# Altium schematic sheet main.SchDoc(main)
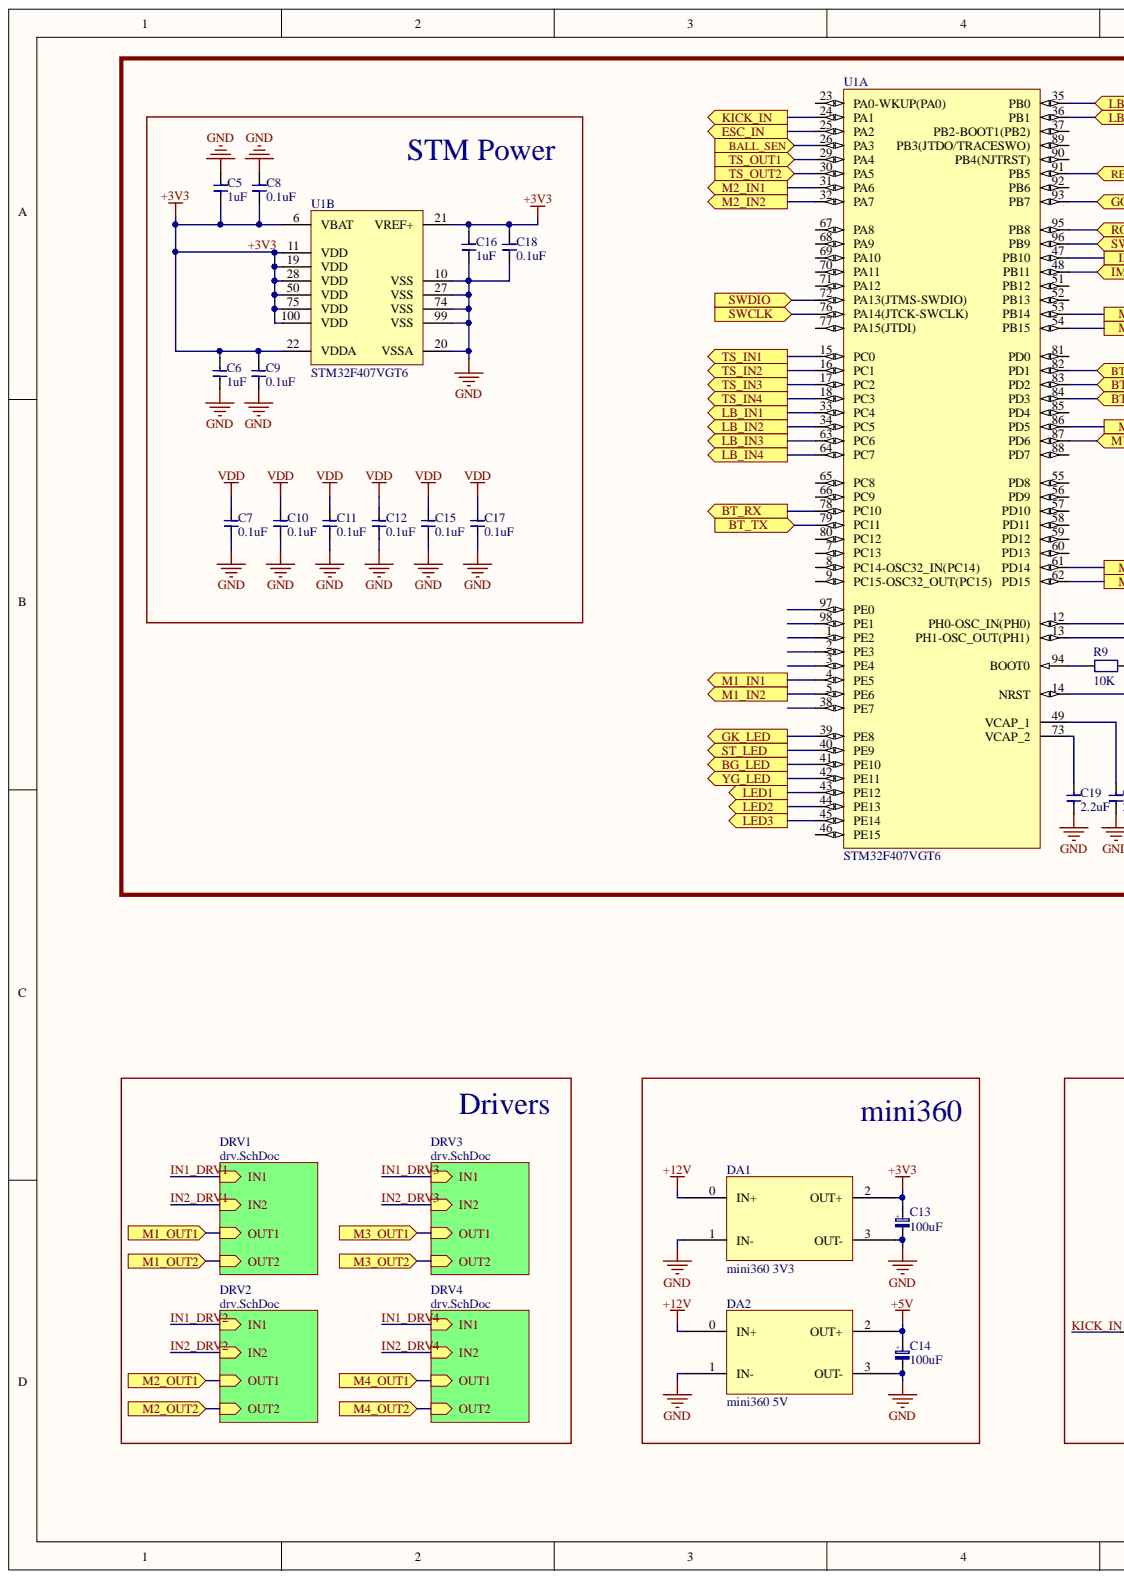
[diagram p0: main.SchDoc, left]
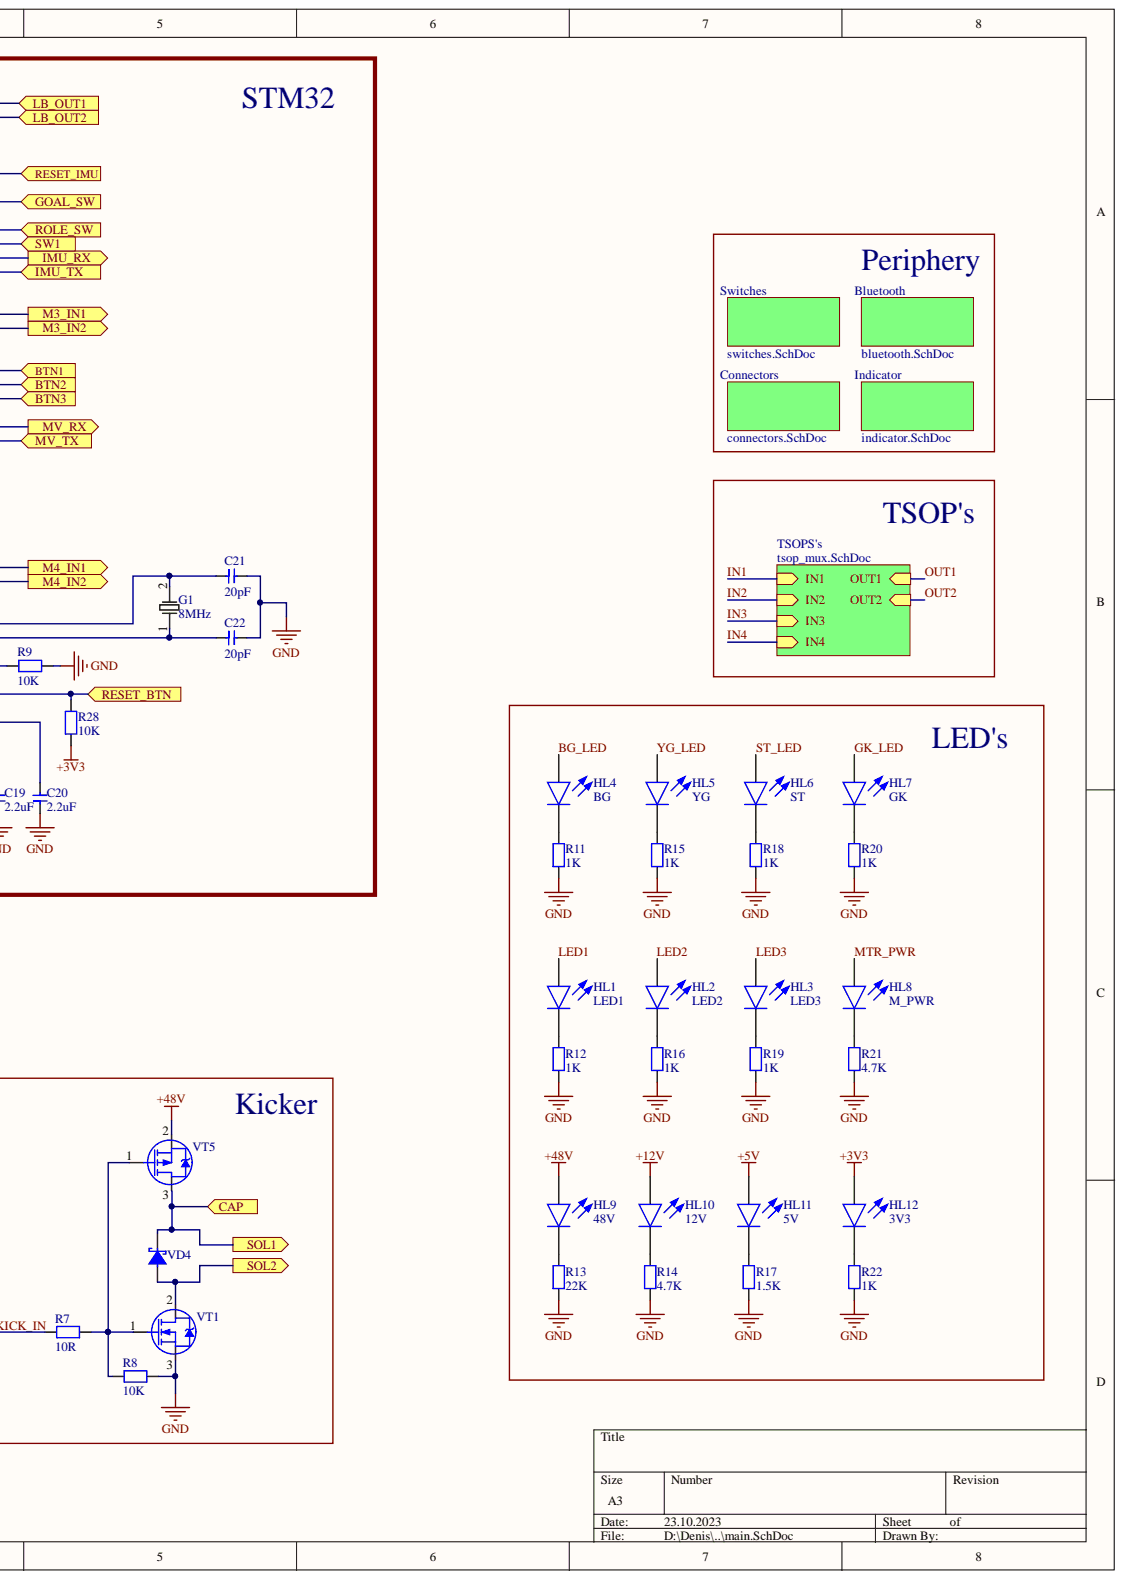
[diagram p1: main.SchDoc, right]

## Parts
C5  1uF  [CAP0603]
C6  1uF  [CAP0603]
C7  0.1uF  [CAP0603]
C8  0.1uF  [CAP0603]
C9  0.1uF  [CAP0603]
C10  0.1uF  [CAP0603]
C11  0.1uF  [CAP0603]
C12  0.1uF  [CAP0603]
C13  100uF  [CAP_TANT_D]
C14  100uF  [CAP_TANT_D]
C15  0.1uF  [CAP0603]
C16  1uF  [CAP0603]
C17  0.1uF  [CAP0603]
C18  0.1uF  [CAP0603]
C19  2.2uF  [CAP0603]
C20  2.2uF  [CAP0603]
C21  20pF  [CAP0603]
C22  20pF  [CAP0603]
DA1  mini360 3V3  [mini360]
DA2  mini360 5V  [mini360]
G1  8MHz  [CRYSTAL_HC49-US-SM]
HL1  LED1  [LED0603_GREEN]
HL2  LED2  [LED0603_GREEN]
HL3  LED3  [LED0603_GREEN]
HL4  BG  [LED0603_GREEN]
HL5  YG  [LED0603_GREEN]
HL6  ST  [LED0603_GREEN]
HL7  GK  [LED0603_GREEN]
HL8  M_PWR  [LED0603_RED]
HL9  48V  [LED0603_RED]
HL10  12V  [LED0603_RED]
HL11  5V  [LED0603_RED]
HL12  3V3  [LED0603_RED]
R7  10R  [RES0805]
R8  10K  [RES0805]
R9  10K  [RES0805]
R11  1K  [RES0805]
R12  1K  [RES0805]
R13  22K  [RES0805]
R14  4.7K  [RES0805]
R15  1K  [RES0805]
R16  1K  [RES0805]
R17  1.5K  [RES0805]
R18  1K  [RES0805]
R19  1K  [RES0805]
R20  1K  [RES0805]
R21  4.7K  [RES0805]
R22  1K  [RES0805]
R28  10K  [RES0805]
U1  STM32F407VGT6  [LQFP100]  (parts A,B)
VD4  Diode Schottky  [DO-214AC]  (value not drawn)
VT1  N-Channel Mosfet  [TO252AA]  (value not drawn)
VT5  IRFR9024N  [TO252AA]  (value not drawn)

## Ports
BALL_SEN, BG_LED, BT_RX, BT_TX, BTN1, BTN2, BTN3, CAP, ESC_IN, GK_LED, GOAL_SW, IMU_RX, IMU_TX, KICK_IN, LB_IN1, LB_IN2, LB_IN3, LB_IN4, LB_OUT1, LB_OUT2, LED1, LED2, LED3, M1_IN1, M1_IN2, M1_OUT1, M1_OUT2, M2_IN1, M2_IN2, M2_OUT1, M2_OUT2, M3_IN1, M3_IN2, M3_OUT1, M3_OUT2, M4_IN1, M4_IN2, M4_OUT1, M4_OUT2, MV_RX, MV_TX, RESET_BTN, RESET_IMU, ROLE_SW, SOL1, SOL2, ST_LED, SW1, SWCLK, SWDIO, TS_IN1, TS_IN2, TS_IN3, TS_IN4, TS_OUT1, TS_OUT2, YG_LED

## Nets
+5V: C14.2 DA2.2(OUT+) HL11.2
+12V: DA1.0(IN+) DA2.0(IN+) HL10.2
+48V: HL9.2 VT5.2
GND: C5.2 C6.1 C7.1 C8.2 C9.1 C10.1 C11.1 C12.1 C13.1 C14.1 C15.1 C16.1 C17.1 C18.1 C19.1 C20.1 C21.1 C22.1 DA1.1(IN-) DA1.3(OUT-) DA2.1(IN-) DA2.3(OUT-) R8.1 R9.2 R11.1 R12.1 R13.1 R14.1 R15.1 R16.1 R17.1 R18.1 R19.1 R20.1 R21.1 R22.1 U1.10(VSS) U1.20(VSSA) U1.27(VSS) U1.74(VSS) U1.99(VSS) VT1.3
BALL_SEN: U1.26(PA3) ; port BALL_SEN
BG_LED: HL4.2
BT_RX: U1.78(PC10) ; port BT_RX
BT_TX: U1.79(PC11) ; port BT_TX
BTN1: U1.82(PD1) ; port BTN1
BTN2: U1.83(PD2) ; port BTN2
BTN3: U1.84(PD3) ; port BTN3
CAP: VD4.1 VT5.3 ; port CAP, port SOL1
ESC_IN: U1.25(PA2) ; port ESC_IN
GK_LED: HL7.2
GOAL_SW: U1.93(PB7) ; port GOAL_SW
IMU_RX: U1.47(PB10) ; port IMU_RX
IMU_TX: U1.48(PB11) ; port IMU_TX
KICK_IN: R7.2
LB_IN1: U1.33(PC4) ; port LB_IN1
LB_IN2: U1.34(PC5) ; port LB_IN2
LB_IN3: U1.63(PC6) ; port LB_IN3
LB_IN4: U1.64(PC7) ; port LB_IN4
LB_OUT1: U1.35(PB0) ; port LB_OUT1
LB_OUT2: U1.36(PB1) ; port LB_OUT2
LED1: HL1.2
LED2: HL2.2
LED3: HL3.2
M1_IN1: U1.4(PE5) ; port M1_IN1
M1_IN2: U1.5(PE6) ; port M1_IN2
M2_IN1: U1.31(PA6) ; port M2_IN1
M2_IN2: U1.32(PA7) ; port M2_IN2
M3_IN1: U1.53(PB14) ; port M3_IN1
M3_IN2: U1.54(PB15) ; port M3_IN2
M4_IN1: U1.61(PD14) ; port M4_IN1
M4_IN2: U1.62(PD15) ; port M4_IN2
MTR_PWR: HL8.2
MV_RX: U1.86(PD5) ; port MV_RX
MV_TX: U1.87(PD6) ; port MV_TX
RESET_BTN: R28.2 U1.14(NRST) ; port RESET_BTN
RESET_IMU: U1.91(PB5) ; port RESET_IMU
ROLE_SW: U1.95(PB8) ; port ROLE_SW
SOL2: VD4.2 VT1.2 ; port SOL2
ST_LED: HL6.2
SW1: U1.96(PB9) ; port SW1
SWCLK: U1.76(PA14(JTCK-SWCLK)) ; port SWCLK
SWDIO: U1.72(PA13(JTMS-SWDIO)) ; port SWDIO
TS_IN1: U1.15(PC0) ; port TS_IN1
TS_IN2: U1.16(PC1) ; port TS_IN2
TS_IN3: U1.17(PC2) ; port TS_IN3
TS_IN4: U1.18(PC3) ; port TS_IN4
TS_OUT1: U1.29(PA4) ; port TS_OUT1
TS_OUT2: U1.30(PA5) ; port TS_OUT2
VDD: C5.1 C6.2 C7.2 C8.1 C9.2 C10.2 C11.2 C12.2 C13.2 C15.2 C16.2 C17.2 C18.2 DA1.2(OUT+) HL12.2 R28.1 U1.6(VBAT) U1.11(VDD) U1.19(VDD) U1.21(VREF+) U1.22(VDDA) U1.28(VDD) U1.50(VDD) U1.75(VDD) U1.100(VDD)
YG_LED: HL5.2
NetC19_2: C19.2 U1.73(VCAP_2)
NetC20_2: C20.2 U1.49(VCAP_1)
NetC21_2: C21.2 G1.2 U1.12(PH0-OSC_IN(PH0))
NetC22_2: C22.2 G1.1 U1.13(PH1-OSC_OUT(PH1))
NetHL1_1: HL1.1 R12.2
NetHL2_1: HL2.1 R16.2
NetHL3_1: HL3.1 R19.2
NetHL4_1: HL4.1 R11.2
NetHL5_1: HL5.1 R15.2
NetHL6_1: HL6.1 R18.2
NetHL7_1: HL7.1 R20.2
NetHL8_1: HL8.1 R21.2
NetHL9_1: HL9.1 R13.2
NetHL10_1: HL10.1 R14.2
NetHL11_1: HL11.1 R17.2
NetHL12_1: HL12.1 R22.2
NetR7_1: R7.1 R8.2 VT1.1 VT5.1
NetR9_1: R9.1 U1.94(BOOT0)
NetU1_1: U1.1(PE2)
NetU1_2: U1.2(PE3)
NetU1_3: U1.3(PE4)
NetU1_7: U1.7(PC13)
NetU1_8: U1.8(PC14-OSC32_IN(PC14))
NetU1_9: U1.9(PC15-OSC32_OUT(PC15))
NetU1_23: U1.23(PA0-WKUP(PA0))
NetU1_24: U1.24(PA1) ; port KICK_IN
NetU1_37: U1.37(PB2-BOOT1(PB2))
NetU1_38: U1.38(PE7)
NetU1_39: U1.39(PE8) ; port GK_LED
NetU1_40: U1.40(PE9) ; port ST_LED
NetU1_41: U1.41(PE10) ; port BG_LED
NetU1_42: U1.42(PE11) ; port YG_LED
NetU1_43: U1.43(PE12) ; port LED1
NetU1_44: U1.44(PE13) ; port LED2
NetU1_45: U1.45(PE14) ; port LED3
NetU1_46: U1.46(PE15)
NetU1_51: U1.51(PB12)
NetU1_52: U1.52(PB13)
NetU1_55: U1.55(PD8)
NetU1_56: U1.56(PD9)
NetU1_57: U1.57(PD10)
NetU1_58: U1.58(PD11)
NetU1_59: U1.59(PD12)
NetU1_60: U1.60(PD13)
NetU1_65: U1.65(PC8)
NetU1_66: U1.66(PC9)
NetU1_67: U1.67(PA8)
NetU1_68: U1.68(PA9)
NetU1_69: U1.69(PA10)
NetU1_70: U1.70(PA11)
NetU1_71: U1.71(PA12)
NetU1_77: U1.77(PA15(JTDI))
NetU1_80: U1.80(PC12)
NetU1_81: U1.81(PD0)
NetU1_85: U1.85(PD4)
NetU1_88: U1.88(PD7)
NetU1_89: U1.89(PB3(JTDO/TRACESWO))
NetU1_90: U1.90(PB4(NJTRST))
NetU1_92: U1.92(PB6)
NetU1_97: U1.97(PE0)
NetU1_98: U1.98(PE1)
M1_IN1:
M1_IN2:
M2_IN1:
M2_IN2:
M3_IN1:
M3_IN2:
M4_IN1:
M4_IN2:
OUT1: ; port M1_OUT1, port M2_OUT1, port M3_OUT1, port M4_OUT1
OUT2: ; port M1_OUT2, port M2_OUT2, port M3_OUT2, port M4_OUT2
TS_IN1:
TS_IN2:
TS_IN3:
TS_IN4:
TS_OUT1:
TS_OUT2:

## Library data of the parts
C5-C12 C15-C22 = CAP Ceramic: Ceramic SMD Cap; Component Kind: Standard; Library Name: Asterisk.SchLib
C13 C14 = CAP Tantal: Tantal SMD Cap; Component Kind: Standard; Library Name: Asterisk.SchLib
DA1 DA2 = mini360: Component Kind: Standard; Library Name: Asterisk.SchLib
G1 = ECS-160-20-5PX-TR: CRYSTAL 16.0000MHZ 20PF SMD; Database Table Name: GeneratorsCrystals; Part Number: ECS-160-20-5PX-TR; HelpURL: https://www.digikey.com/product-detail/en/ecs-inc/ECS-160-20-5PX-TR/XC1282CT-ND/827746; Component Kind: Standard; Library Name: SssAccesDatbase.DbLib
HL1-HL7 = LED: LED smd Green; Component Kind: Standard; Library Name: Asterisk.SchLib
HL8-HL12 = LED: LED smd Red; Component Kind: Standard; Library Name: Asterisk.SchLib
R7-R9 R11-R22 R28 = RES: RES smd; Component Kind: Standard; Library Name: Asterisk.SchLib
U1 = STM32F407VGT6: ARM Cortex-M4 32-bit MCU+FPU, 210 DMIPS, 1024 kB Flash, 192 kB Internal RAM, 82 I/Os, 100-pin LQFP, -40 to 85 degC, Tray; Manufacturer: STMicroelectronics; Supply Voltage(Vcc) min (V): 1.8; Supply Voltage(Vcc) max (V): 3.6; Operating Frequency(F) (Processor speed) (MHz): 168; Internal RAM Size (kB): 192; I/Os (High Current): 82; FLASH Size (Prog) (kB): 1024; D/A Converter: 2x12-bit; Supplier Part Number 4: 511-STM32F407VGT6; Supplier Part Number 3: 73T2333; Supplier Part Number 2: 2064368; Supplier Part Number 1: 497-11605-ND; Supplier 4: Mouser; Supplier 3: Newark; Supplier 2: Farnell; Supplier 1: Digi-Key; PartNumber: STM32F407VGT6; Packing: Tray; PackageVersion: Rev. 1, 06/2013; PackageReference: LQFP100; PackageDescription: 100-Pin Low Profile Quad Flat Package, 14 x 14 mm Body, 0.5 mm Pitch; Mounting Technology: Surface Mount; DatasheetVersion: Rev. 4; Core: ARM Cortex-M4; ComponentLink3URL: http://www.st.com/st-web-ui/static/active/en/resource/technical/document/data_brief/DM00071938.pdf; ComponentLink3Description: Package Specification; ComponentLink2URL: http://www.st.com/st-web-ui/static/active/en/resource/technical/document/datasheet/DM00037051.pdf; ComponentLink2Description: Datasheet; ComponentLink1URL: http://www.st.com/web/en/home.html; ComponentLink1Description: Manufacturer URL; Component Kind: Standard; Library Name: Asterisk.SchLib
VT1 = IRFR2405: DPAK; Database Table Name: Transistor; Part Number: IRFR2405; Component Kind: Standard; Library Name: SssAccesDatbase.DbLib
VT5 = IRFR9024N: Database Table Name: Transistor; Part Number: IRFR9024N; Component Kind: Standard; Library Name: SssAccesDatbase.DbLib
VD4 = Diode Schottky: Component Kind: Standard; Library Name: Asterisk.SchLib
